# Altium schematic sheet MPU_Signal.SchDoc
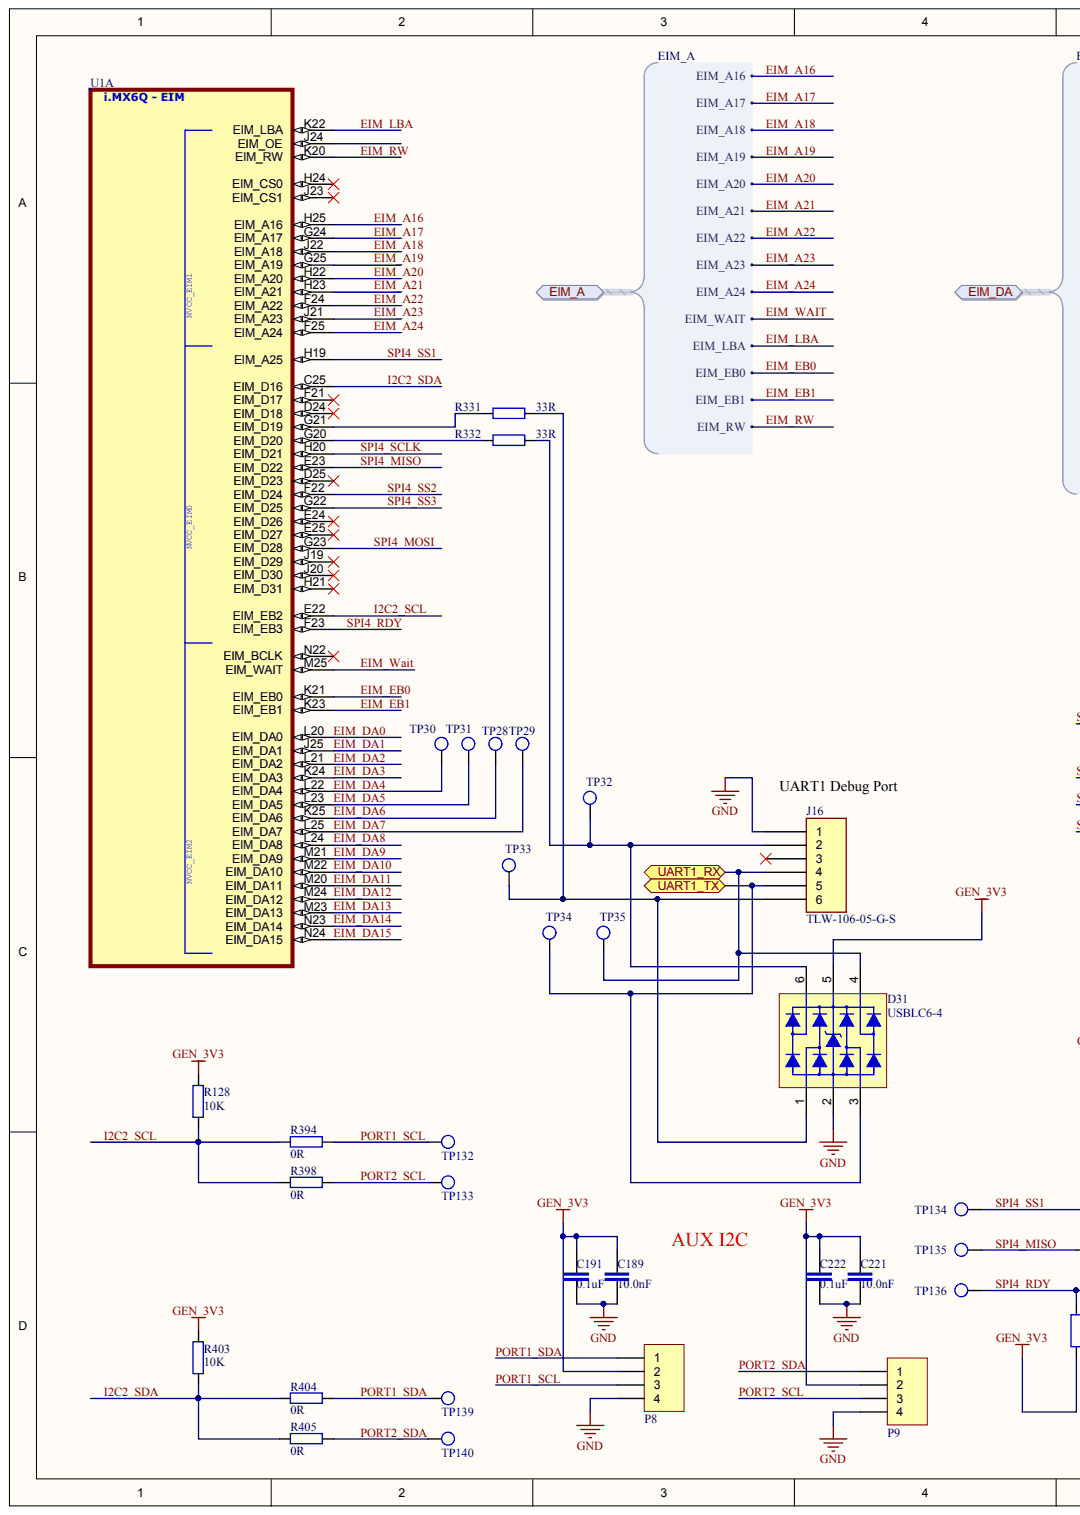
[diagram p0: MPU_Signal.SchDoc, left]
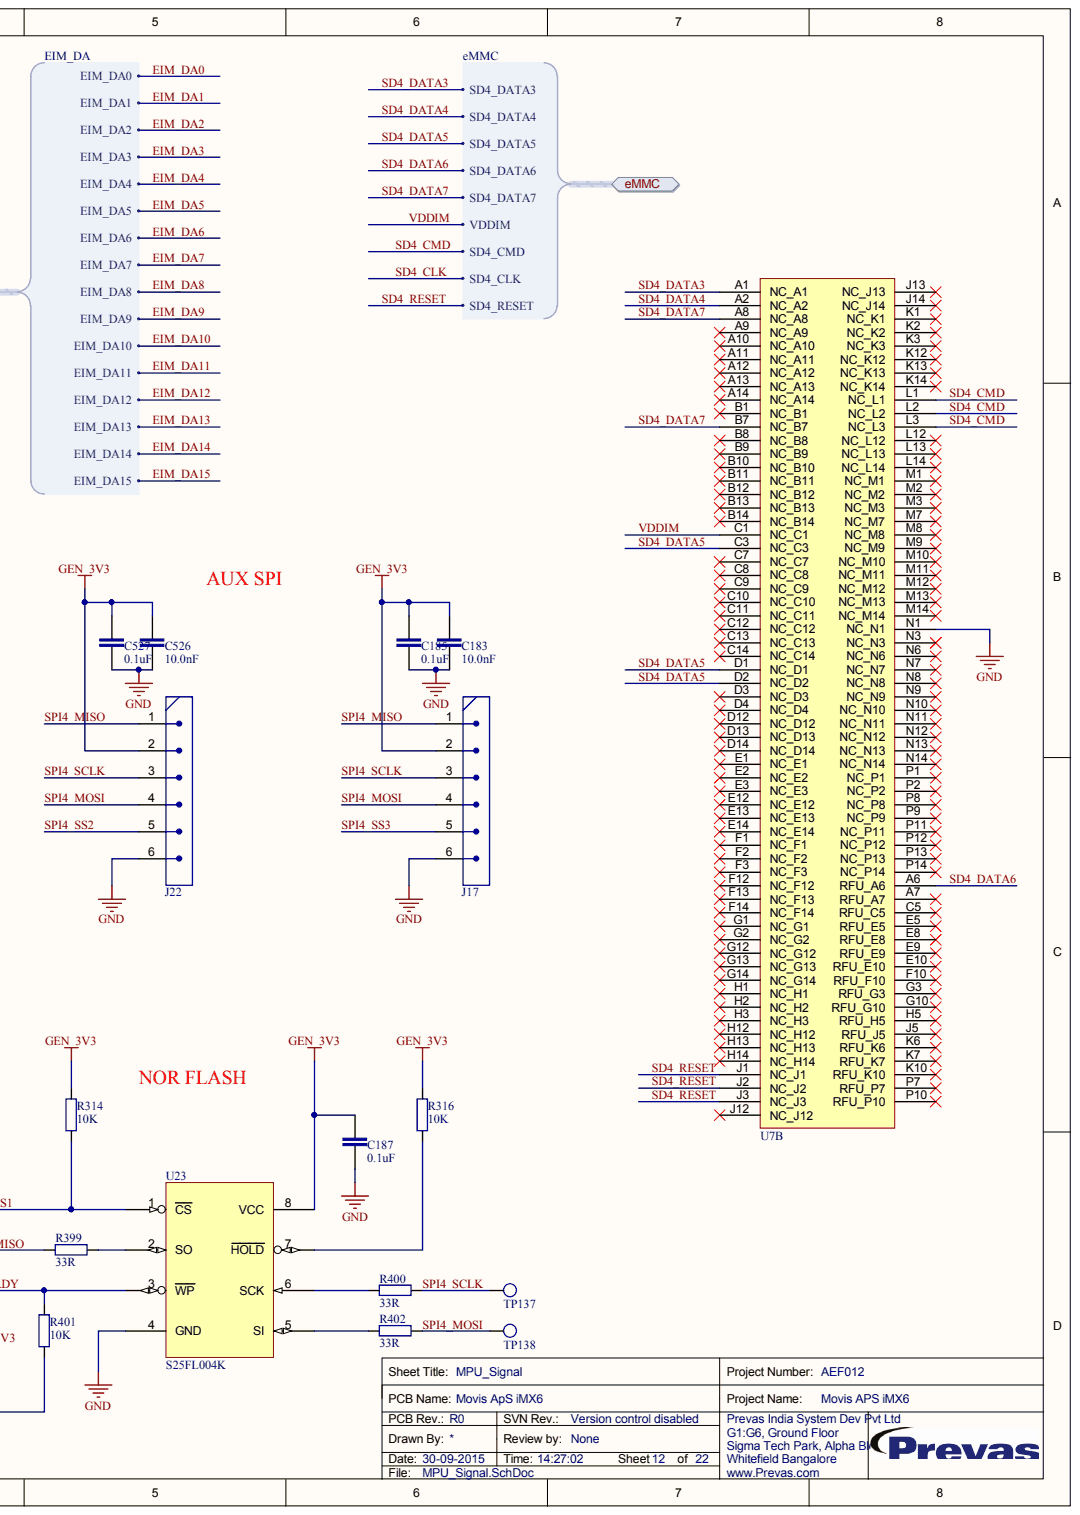
[diagram p1: MPU_Signal.SchDoc, right]

## Parts
C183  10.0nF  [CAPC1005X55N]
C185  0.1uF  [CAPC1005X55N]
C187  0.1uF  [CAPC1005X55N]
C189  10.0nF  [CAPC1005X55N]
C191  0.1uF  [CAPC1005X55N]
C221  10.0nF  [CAPC1005X55N]
C222  0.1uF  [CAPC1005X55N]
C526  10.0nF  [CAPC1005X55N]
C527  0.1uF  [CAPC1005X55N]
D31  USBLC6-4  [SOT23-6L]
J16  TLW-106-05-G-S  [MOLEX_90120-6]
J17  HDR_6  [MOLEX_53398-6]  (value not drawn)
J22  HDR_6  [MOLEX_53398-6]  (value not drawn)
P8  533980471  [MOLEX_53398-4]  (value not drawn)
P9  533980471  [MOLEX_53398-4]  (value not drawn)
R128  10K  [RESC1608X55N]
R314  10K  [RESC1608X55N]
R316  10K  [RESC1608X55N]
R331  33R  [RESC1608X55N]
R332  33R  [RESC1608X55N]
R394  0R  [RESC1608X55N]
R398  0R  [RESC1608X55N]
R399  33R  [RESC1608X55N]
R400  33R  [RESC1608X55N]
R401  10K  [RESC1608X55N]
R402  33R  [RESC1608X55N]
R403  10K  [RESC1608X55N]
R404  0R  [RESC1608X55N]
R405  0R  [RESC1608X55N]
TP28  Testpoint  [TP_SMD]  (value not drawn)  (no BOM)
TP29  Testpoint  [TP_SMD]  (value not drawn)  (no BOM)
TP30  Testpoint  [TP_SMD]  (value not drawn)  (no BOM)
TP31  Testpoint  [TP_SMD]  (value not drawn)  (no BOM)
TP32  Testpoint  [TP_SMD]  (value not drawn)  (no BOM)
TP33  Testpoint  [TP_SMD]  (value not drawn)  (no BOM)
TP34  Testpoint  [TP_SMD]  (value not drawn)  (no BOM)
TP35  Testpoint  [TP_SMD]  (value not drawn)  (no BOM)
TP132  Testpoint  [TP_SMD]  (value not drawn)  (no BOM)
TP133  Testpoint  [TP_SMD]  (value not drawn)  (no BOM)
TP134  Testpoint  [TP_SMD]  (value not drawn)  (no BOM)
TP135  Testpoint  [TP_SMD]  (value not drawn)  (no BOM)
TP136  Testpoint  [TP_SMD]  (value not drawn)  (no BOM)
TP137  Testpoint  [TP_SMD]  (value not drawn)  (no BOM)
TP138  Testpoint  [TP_SMD]  (value not drawn)  (no BOM)
TP139  Testpoint  [TP_SMD]  (value not drawn)  (no BOM)
TP140  Testpoint  [TP_SMD]  (value not drawn)  (no BOM)
U1  MCIMX6Q6AVT10AC  [BGA624C80P25X25_2100X2100X160]  (value not drawn)  (part A)
U7  MTFC4GACAAAM-1M WT  [BGA153C50P14X14_1155X1305X100]  (value not drawn)  (part B)
U23  S25FL004K  [SOIC127P787X216-8AN]

## Ports
EIM_A, EIM_A.EIM_A16, EIM_A.EIM_A17, EIM_A.EIM_A18, EIM_A.EIM_A19, EIM_A.EIM_A20, EIM_A.EIM_A21, EIM_A.EIM_A22, EIM_A.EIM_A23, EIM_A.EIM_A24, EIM_A.EIM_EB0, EIM_A.EIM_EB1, EIM_A.EIM_LBA, EIM_A.EIM_RW, EIM_A.EIM_WAIT, EIM_DA, EIM_DA.EIM_DA0, EIM_DA.EIM_DA1, EIM_DA.EIM_DA2, EIM_DA.EIM_DA3, EIM_DA.EIM_DA4, EIM_DA.EIM_DA5, EIM_DA.EIM_DA6, EIM_DA.EIM_DA7, EIM_DA.EIM_DA8, EIM_DA.EIM_DA9, EIM_DA.EIM_DA10, EIM_DA.EIM_DA11, EIM_DA.EIM_DA12, EIM_DA.EIM_DA13, EIM_DA.EIM_DA14, EIM_DA.EIM_DA15, EMMC, EMMC.SD4_CLK, EMMC.SD4_CMD, EMMC.SD4_DATA3, EMMC.SD4_DATA4, EMMC.SD4_DATA5, EMMC.SD4_DATA6, EMMC.SD4_DATA7, EMMC.SD4_RESET, EMMC.VDDIM, UART1_RX, UART1_TX

## Nets
GEN_3V3: C183.2 C185.2 C187.1 C189.2 C191.2 C221.2 C222.2 C526.2 C527.2 D31.5 J17.2 J22.2 P8.2 P9.2 R128.2 R314.1 R316.1 R401.2 R403.2 U23.8(VCC)
GND: C183.1 C185.1 C187.2 C189.1 C191.1 C221.1 C222.1 C526.1 C527.1 D31.2 J16.1 J17.6 J22.6 P8.4 P9.4 U7.N1(NC_N1) U23.4(GND)
EIM_A16: U1.H25(EIM_A16) ; port EIM_A
EIM_A17: U1.G24(EIM_A17) ; port EIM_A
EIM_A18: U1.J22(EIM_A18) ; port EIM_A
EIM_A19: U1.G25(EIM_A19) ; port EIM_A
EIM_A20: U1.H22(EIM_A20) ; port EIM_A
EIM_A21: U1.H23(EIM_A21) ; port EIM_A
EIM_A22: U1.F24(EIM_A22) ; port EIM_A
EIM_A23: U1.J21(EIM_A23) ; port EIM_A
EIM_A24: U1.F25(EIM_A24) ; port EIM_A
EIM_DA0: U1.L20(EIM_DA0) ; port EIM_DA
EIM_DA1: U1.J25(EIM_DA1) ; port EIM_DA
EIM_DA2: U1.L21(EIM_DA2) ; port EIM_DA
EIM_DA3: U1.K24(EIM_DA3) ; port EIM_DA
EIM_DA4: TP30.1 U1.L22(EIM_DA4) ; port EIM_DA
EIM_DA5: TP31.1 U1.L23(EIM_DA5) ; port EIM_DA
EIM_DA6: TP28.1 U1.K25(EIM_DA6) ; port EIM_DA
EIM_DA7: TP29.1 U1.L25(EIM_DA7) ; port EIM_DA
EIM_DA8: U1.L24(EIM_DA8) ; port EIM_DA
EIM_DA9: U1.M21(EIM_DA9) ; port EIM_DA
EIM_DA10: U1.M22(EIM_DA10) ; port EIM_DA
EIM_DA11: U1.M20(EIM_DA11) ; port EIM_DA
EIM_DA12: U1.M24(EIM_DA12) ; port EIM_DA
EIM_DA13: U1.M23(EIM_DA13) ; port EIM_DA
EIM_DA14: U1.N23(EIM_DA14) ; port EIM_DA
EIM_DA15: U1.N24(EIM_DA15) ; port EIM_DA
EIM_EB0: U1.K21(EIM_EB0) ; port EIM_A
EIM_EB1: U1.K23(EIM_EB1) ; port EIM_A
EIM_LBA: U1.K22(EIM_LBA) ; port EIM_A
EIM_RW: U1.K20(EIM_RW) ; port EIM_A
EIM_WAIT: U1.M25(EIM_WAIT) ; port EIM_A
I2C2_SCL: R128.1 R394.1 R398.1 U1.E22(EIM_EB2)
I2C2_SDA: R403.1 R404.1 R405.1 U1.C25(EIM_D16)
PORT1_SCL: P8.3 R394.2 TP132.1
PORT1_SDA: P8.1 R404.2 TP139.1
PORT2_SCL: P9.3 R398.2 TP133.1
PORT2_SDA: P9.1 R405.2 TP140.1
SD4_CMD: U7.L1(NC_L1) U7.L2(NC_L2) U7.L3(NC_L3) ; port EMMC
SD4_DATA3: U7.A1(NC_A1) ; port EMMC
SD4_DATA4: U7.A2(NC_A2) ; port EMMC
SD4_DATA5: U7.C3(NC_C3) U7.D1(NC_D1) U7.D2(NC_D2) ; port EMMC
SD4_DATA6: U7.A6(RFU_A6) ; port EMMC
SD4_DATA7: U7.A8(NC_A8) U7.B7(NC_B7) ; port EMMC
SD4_RESET: U7.J1(NC_J1) U7.J2(NC_J2) U7.J3(NC_J3) ; port EMMC
SPI4_MISO: J17.1 J22.1 R399.1 TP135.1 U1.E23(EIM_D22)
SPI4_MOSI: J17.4 J22.4 R402.2 TP138.1 U1.G23(EIM_D28)
SPI4_RDY: R401.1 TP136.1 U1.F23(EIM_EB3) U23.3(WP)
SPI4_SCLK: J17.3 J22.3 R400.2 TP137.1 U1.H20(EIM_D21)
SPI4_SS1: R314.2 TP134.1 U1.H19(EIM_A25) U23.1(CS)
SPI4_SS2: J22.5 U1.F22(EIM_D24)
SPI4_SS3: J17.5 U1.G22(EIM_D25)
UART1_RX: D31.4 J16.4 TP35.1 ; port UART1_RX
UART1_TX: D31.3 J16.5 TP34.1 ; port UART1_TX
VDDIM: U7.C1(NC_C1) ; port EMMC
NetD31_1: D31.1 J16.6 R331.1 TP33.1
NetD31_6: D31.6 J16.2 R332.1 TP32.1
NetR316_2: R316.2 U23.7(HOLD)
NetR331_2: R331.2 U1.G21(EIM_D19)
NetR332_2: R332.2 U1.G20(EIM_D20)
NetR399_2: R399.2 U23.2(SO)
NetR400_1: R400.1 U23.6(SCK)
NetR402_1: R402.1 U23.5(SI)
SD4_CLK: ; port EMMC

Not connected: J16 3; U1 D24(EIM_D18) D25(EIM_D23) E24(EIM_D26) E25(EIM_D27) F21(EIM_D17) H21(EIM_D31) H24(EIM_CS0) J19(EIM_D29) J20(EIM_D30) J23(EIM_CS1) J24(EIM_OE) N22(EIM_BCLK); U7 A7(RFU_A7) A9(NC_A9) A10(NC_A10) A11(NC_A11) A12(NC_A12) A13(NC_A13) A14(NC_A14) B1(NC_B1) B8(NC_B8) B9(NC_B9) B10(NC_B10) B11(NC_B11) B12(NC_B12) B13(NC_B13) B14(NC_B14) C5(RFU_C5) C7(NC_C7) C8(NC_C8) C9(NC_C9) C10(NC_C10) C11(NC_C11) C12(NC_C12) C13(NC_C13) C14(NC_C14) D3(NC_D3) D4(NC_D4) D12(NC_D12) D13(NC_D13) D14(NC_D14) E1(NC_E1) E2(NC_E2) E3(NC_E3) E5(RFU_E5) E8(RFU_E8) E9(RFU_E9) E10(RFU_E10) E12(NC_E12) E13(NC_E13) E14(NC_E14) F1(NC_F1) F2(NC_F2) F3(NC_F3) F10(RFU_F10) F12(NC_F12) F13(NC_F13) F14(NC_F14) G1(NC_G1) G2(NC_G2) G3(RFU_G3) G10(RFU_G10) G12(NC_G12) G13(NC_G13) G14(NC_G14) H1(NC_H1) H2(NC_H2) H3(NC_H3) H5(RFU_H5) H12(NC_H12) H13(NC_H13) H14(NC_H14) J5(RFU_J5) J12(NC_J12) J13(NC_J13) J14(NC_J14) K1(NC_K1) K2(NC_K2) K3(NC_K3) K6(RFU_K6) K7(RFU_K7) K10(RFU_K10) K12(NC_K12) K13(NC_K13) K14(NC_K14) L12(NC_L12) L13(NC_L13) L14(NC_L14) M1(NC_M1) M2(NC_M2) M3(NC_M3) M7(NC_M7) M8(NC_M8) M9(NC_M9) M10(NC_M10) M11(NC_M11) M12(NC_M12) M13(NC_M13) M14(NC_M14) N3(NC_N3) N6(NC_N6) N7(NC_N7) N8(NC_N8) N9(NC_N9) N10(NC_N10) N11(NC_N11) N12(NC_N12) N13(NC_N13) N14(NC_N14) P1(NC_P1) P2(NC_P2) P7(RFU_P7) P8(NC_P8) P9(NC_P9) P10(RFU_P10) P11(NC_P11) P12(NC_P12) P13(NC_P13) P14(NC_P14)

## Library data of the parts
C183 C189 C221 C526 = INNISI-82: CAP CER 10nF 50V 10% X7R 0402; ref_part_type: /capacitor/non_polarized/ceramic/; source_manufacturer_1: Yageo; source_manufacturer_1_pn: CC0402KRX7R9BB103; cae_altium_pcb_status: Prototype; Database Table Name: altium_default; db_rev: 7579; elec_temp_range: -55 to +125C; phy_height: 0.55mm; phy_mounting: SMD; phy_packaging_type: 0402; ref_datasheet: INNISI-72; ref_keyword: Ceramic capacitor; cae_altium_sch_status: Prototype; Component Kind: Standard; ComponentLink1Description: Datasheet; ComponentLink1URL: https://cop201.prevas.dk/viewvc/datasheet/INNISI-72.pdf?root=hw_components&view=co; db_created: 2015-08-03 05:52:48.0; db_last_updated: 03-08-2015 05:52:50; db_local_id: INNISI-82; db_status: Prototype; elec_tolerance: 10%; elec_value: 10.0nF; elec_voltage_rating: 50V; phy_material: X7R; Library Name: altium_mysql.dblib
C185 C187 C191 C222 C527 = INNISI-73: CAP CER 0.1UF 50V 10% X5R 0402; ref_part_type: /capacitor/non_polarized/ceramic/; source_manufacturer_1: TDK Corporation; source_manufacturer_1_pn: C1005X5R1H104K050BB; cae_altium_pcb_status: Prototype; Database Table Name: altium_default; db_rev: 7569; elec_temp_range: -55 to +85 C; phy_height: 0.55mm; phy_mounting: SMD; phy_packaging_type: 0402; ref_datasheet: INNISI-71; cae_altium_sch_status: Prototype; Component Kind: Standard; ComponentLink1Description: Datasheet; ComponentLink1URL: https://cop201.prevas.dk/viewvc/datasheet/INNISI-71.pdf?root=hw_components&view=co; db_created: 2015-08-03 03:34:59.0; db_last_updated: 03-08-2015 03:35:01; db_local_id: INNISI-73; db_status: Prototype; elec_tolerance: ±10%; elec_value: 0.1uF; elec_voltage_rating: 50V; phy_material: X5R; ref_keyword: CAP CER 0.1UF 50V 10% X5R 0402; Library Name: altium_mysql.dblib
  C187: Mounted: Yes
D31 = NIMA-155: Dual very low capacitance ESD protection for USB 2.0 up to 480Mb/s; ref_part_type: /diode/transient/; source_manufacturer_1: STMicroelectronics; cae_altium_pcb_status: Prototype; Database Table Name: altium_default; db_rev: 1817; elec_temp_range: -40 to 125; elec_voltage_rating: 5V; phy_height: 1.45mm; phy_mounting: SMD; ref_datasheet: NIMA-115; cae_altium_sch_status: Prototype; Component Kind: Standard; ComponentLink1Description: Datasheet; ComponentLink1URL: https://cop201.prevas.dk/viewvc/datasheet/NIMA-115.pdf?root=hw_components&view=co; db_created: 2009-09-25 21:20:59; db_last_updated: 25-09-2009 21:21:20; db_local_id: NIMA-155; db_status: Prototype; elec_value: USBLC6-4; phy_packaging_type: SOT23-6L; ref_keyword: ESD; source_manufacturer_1_pn: USBLC6-4; Library Name: altium_mysql.dblib
J16 = BONE-32: HEADER, 2.54MM, SINGLE, 6WAY; ref_part_type: /connector/6 pins/; source_manufacturer_1: SAMTEC; cae_altium_pcb_status: Prototype; Database Table Name: altium_default; db_rev: 1176; elec_current_rating: 3A; elec_temp_range: -55 to 125; phy_height: 5,8mm; phy_mounting: Leaded; phy_packaging_type: Pitch 2.54mm; ref_datasheet: BONE-30; cae_altium_sch_status: Prototype; Component Kind: Standard; ComponentLink1Description: Datasheet; ComponentLink1URL: https://cop201.prevas.dk/viewvc/datasheet/BONE-30.pdf?root=hw_components&view=co; db_created: 2009-03-24 13:23:34; db_last_updated: 15-04-2009 13:18:33; db_local_id: BONE-32; db_status: Prototype; elec_value: TLW-106-05-G-S; ref_keyword: Header; source_manufacturer_1_pn: TLW-106-05-G-S; Library Name: altium_mysql.dblib
J17 J22 = SOMO-114: PicoBlade header 6-pin; ref_part_type: /connector/6 pins/; source_manufacturer_1: Molex; cae_altium_pcb_status: Prototype; Database Table Name: altium_default; db_rev: 4602; elec_current_rating: 1A; elec_temp_range: -40 to +85°C; elec_value: 533980671; elec_voltage_rating: 125V; phy_height: 4.7mm; phy_material: High temperature thermoplastic; phy_mounting: SMD; phy_packaging_type: Vertical; ref_datasheet: MITO-22; cae_altium_sch_status: Prototype; Component Kind: Standard; ComponentLink1Description: Datasheet; ComponentLink1URL: https://cop201.prevas.dk/viewvc/datasheet/MITO-22.pdf?root=hw_components&view=co; db_created: 2012-05-09 15:21:49.0; db_last_updated: 09-05-2012 16:30:37; db_local_id: SOMO-114; db_status: Prototype; ref_keyword: Header; source_manufacturer_1_pn: 533980671; Library Name: altium_mysql.dblib
P8 P9 = MITO-29: PicoBlade header 4-pin; ref_part_type: /connector/4 pins/; source_manufacturer_1: Molex; cae_altium_pcb_status: Prototype; Database Table Name: altium_default; db_rev: 591; elec_current_rating: 1A; elec_temp_range: -40 to +85°C; elec_voltage_rating: 125V; phy_height: 4.7mm; phy_material: High temperature thermoplastic; phy_mounting: SMD; phy_packaging_type: Vertical; ref_datasheet: MITO-23; cae_altium_sch_status: Prototype; Component Kind: Standard; ComponentLink1Description: Datasheet; ComponentLink1URL: https://cop201.prevas.dk/viewvc/datasheet/MITO-23.pdf?root=hw_components&view=co; db_created: 2008-10-14 14:05:56; db_last_updated: 20-11-2008 11:02:42; db_local_id: MITO-29; db_status: Prototype; elec_value: 533980471; ref_keyword: Header; source_manufacturer_1_pn: 533980471; Library Name: altium_mysql.dblib
R128 R314 R316 R401 R403 = INVIHA-3: RES SMD 10K OHM 1% 1/10W 0603; ref_part_type: /resistor/fixed/; source_manufacturer_1: Yageo; source_manufacturer_1_pn: RC0603FR-0710KL; cae_altium_pcb_status: Prototype; Database Table Name: altium_default; db_rev: 7575; elec_power_rating: 0.1W; elec_temp_range: -55 to +155° C; phy_height: 0.55mm; phy_packaging_type: 0603; ref_datasheet: INVIHA-2; ref_keyword: Chip Resistor; cae_altium_sch_status: Prototype; Component Kind: Standard; ComponentLink1Description: Datasheet; ComponentLink1URL: https://cop201.prevas.dk/viewvc/datasheet/INVIHA-2.pdf?root=hw_components&view=co; db_created: 2015-08-03 05:39:03.0; db_last_updated: 03-08-2015 5:39:05 AM; db_local_id: INVIHA-3; db_status: Prototype; elec_tolerance: 1%; elec_value: 10K; phy_mounting: SMD; Library Name: altium_mysql.dblib
  R314 R316 R401: Mounted: Yes
R331 R332 R399 R400 R402 = INVIHA-13: RES SMD 33 OHM 1% 1/10W 0603; ref_part_type: /resistor/fixed/; source_manufacturer_1: Yageo; source_manufacturer_1_pn: RC0603FR-0733RL; cae_altium_pcb_status: Prototype; Database Table Name: altium_default; db_rev: 7593; elec_power_rating: 0.1W; elec_temp_range: -55 to +155° C; phy_height: 0.55mm; phy_packaging_type: 0603; ref_datasheet: INVIHA-2; ref_keyword: Chip Resistor; cae_altium_sch_status: Prototype; Component Kind: Standard; ComponentLink1Description: Datasheet; ComponentLink1URL: https://cop201.prevas.dk/viewvc/datasheet/INVIHA-2.pdf?root=hw_components&view=co; db_created: 2015-08-03 06:49:16.0; db_last_updated: 03-08-2015 6:49:17 AM; db_local_id: INVIHA-13; db_status: Prototype; elec_tolerance: 1%; elec_value: 33R; phy_mounting: SMD; Library Name: altium_mysql.dblib
R394 R398 R404 R405 = INVIHA-4: RES SMD 0.0 OHM JUMPER 1/10W; ref_part_type: /resistor/fixed/; source_manufacturer_1: Panasonic Electronic Components; source_manufacturer_1_pn: ERJ-3GEY0R00V; cae_altium_pcb_status: Prototype; Database Table Name: altium_default; db_rev: 7580; elec_power_rating: 0.1W; elec_temp_range: -55 to +155° C; phy_height: 0.55mm; phy_packaging_type: 0603; ref_datasheet: INVIHA-3; ref_keyword: Chip Resistor; cae_altium_sch_status: Prototype; Component Kind: Standard; ComponentLink1Description: Datasheet; ComponentLink1URL: https://cop201.prevas.dk/viewvc/datasheet/INVIHA-3.pdf?root=hw_components&view=co; db_created: 2015-08-03 05:59:19.0; db_last_updated: 03-08-2015 5:59:21 AM; db_local_id: INVIHA-4; db_status: Prototype; elec_value: 0R; phy_mounting: SMD; Library Name: altium_mysql.dblib
TP28-TP35 TP132-TP140 = JEPE-114: Test Point SMD; ref_part_type: /pcb_item/; cae_altium_pcb_status: Prototype; Database Table Name: altium_default; db_rev: 3281; cae_altium_sch_status: Prototype; ComponentLink1Description: Datasheet; db_created: 2008-08-18 11:39:42; db_last_updated: 23-03-2011 14:14:06; db_local_id: JEPE-114; db_status: Prototype; elec_value: Test Point; phy_mounting: SMD; ref_keyword: Test  Point; Library Name: altium_mysql.dblib
U1 = INRAAN-5: i.MX 6Dual/6Quad; ref_part_type: /integrated/digital/processor/general/; AGILE_PN: 344-01218; CAD_APPROVED: Yes; cae_altium_pcb_status: Prototype; CLASS: IC; Database Table Name: altium_default; db_rev: 6247; elec_temp_range: -40 to +105; elec_voltage_rating: 1.5V; MFG_NAME01: FREESCALE SEMICONDUCTOR; phy_height: 1.85; phy_mounting: SMD; phy_packaging_type: FCPBGA96_624; ref_datasheet: INRAAN-6; cae_altium_sch_status: Prototype; Component Kind: Standard; ComponentLink1Description: Datasheet; ComponentLink1URL: https://cop201.prevas.dk/viewvc/datasheet/INRAAN-6.pdf?root=hw_components&view=co; db_created: 2013-10-21 12:25:57.0; db_last_updated: 27-12-2013 09:32:57; db_local_id: INRAAN-5; db_status: Prototype; elec_value: MCIMX6Q6AVT10AC; HEIGHT: 2.16MM; MFG_PN01: MCIMX6Q6AVT10AC; ref_keyword: i.MX 6Dual/6Quad; ROHS: Yes; source_manufacturer_1: Freescale Semiconductor; source_manufacturer_1_pn: MCIMX6Q6AVT10AC; Library Name: altium_mysql.dblib
U7 = INNISI-7: eMMC Memory,4GB; ref_part_type: /integrated/digital/memory/non_volatile/eraseable/flash/; source_manufacturer_1: Micron; cae_altium_pcb_status: Prototype; Database Table Name: altium_default; db_rev: 7323; elec_temp_range: –25?C to +85?C; elec_voltage_rating: 2.7–3.6V; phy_mounting: SMD; phy_packaging_type: 153-ball VFBGA; cae_altium_sch_status: Prototype; Component Kind: Standard; ComponentLink1Description: Datasheet; ComponentLink1URL: https://cop201.prevas.dk/viewvc/datasheet/INNISI-7.pdf?root=hw_components&view=co; db_created: 2015-05-27 06:58:23.0; db_last_updated: 27-05-2015 06:58:25; db_local_id: INNISI-7; db_status: Prototype; elec_value: MTFC4GACAAAM-1M WT; ref_datasheet: INNISI-7; ref_keyword: eMMC Memory; source_manufacturer_1_pn: MTFC4GACAAAM-1M WT; Library Name: altium_mysql.dblib
U23 = CHPE-132: 4 Mbit quad SPI serial flash memory; ref_part_type: /integrated/digital/memory/non_volatile/eraseable/flash/; source_manufacturer_1: Spansion; source_manufacturer_1_pn: S25FL004K0XMFI01; cae_altium_pcb_status: Prototype; Database Table Name: altium_default; db_rev: 4244; elec_frequency_rating: 104 MHz; elec_temp_range: -40 to +85°C; elec_voltage_rating: 2.7-3.6V; phy_height: 1.75mm; phy_mounting: SMD; phy_packaging_type: SOC8 - 208mil; ref_datasheet: TELA-43; ref_keyword: Serial Flash 50 MB/s; cae_altium_sch_status: Prototype; Component Kind: Standard; ComponentLink1Description: Datasheet; ComponentLink1URL: https://cop201.prevas.dk/viewvc/datasheet/TELA-43.pdf?root=hw_components&view=co; db_created: 2011-05-27 09:26:48.0; db_last_updated: 23-12-2011 13:50:38; db_local_id: CHPE-132; db_status: Prototype; elec_value: S25FL004K; Library Name: altium_mysql.dblib
